# Altium schematic sheet PWR.SchDoc(PWR)
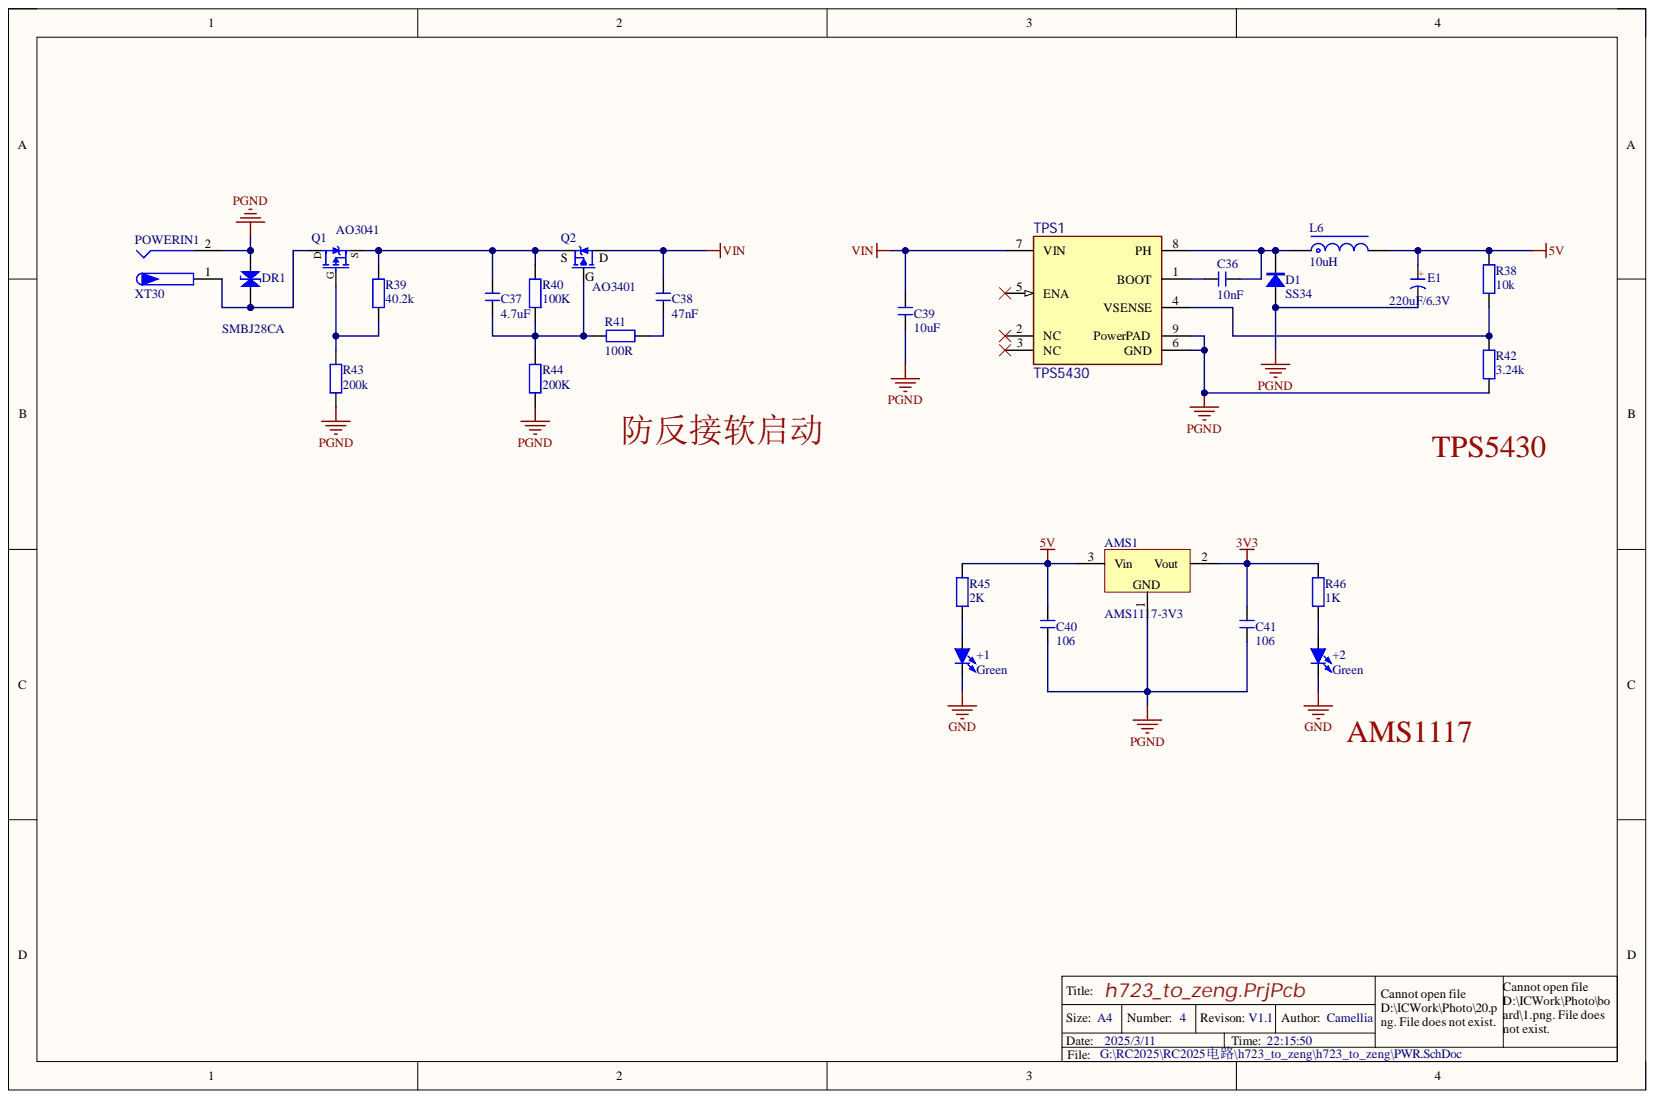
[diagram p0: PWR.SchDoc]

## Parts
+1  Green  [LED 0603G]
+2  Green  [LED 0603G]
AMS1  AMS1117-3V3  [SOT89_L]
C36  10nF  [C 0603_L]
C37  4.7uF  [C 0603_L]
C38  47nF  [C 0603_L]
C39  10uF  [C 1206_M]
C40  106  [C 0603_L]
C41  106  [C 0603_L]
D1  SS34  [SMA]
DR1  SMBJ28CA  [SMB]
E1  220uF/6.3V  [CD B(3528)]
L6  10uH  [NR6045]
POWERIN1  XT30  [XT30PW-M]
Q1  AO3041  [SOT23-3L]
Q2  AO3401  [SOT23-3L]
R38  10k  [R 0603_L]
R39  40.2k  [R 0603_L]
R40  100K  [R 0603_L]
R41  100R  [R 0603_L]
R42  3.24k  [R 0603_L]
R43  200k  [R 0603_L]
R44  200K  [R 0603_L]
R45  2K  [R 0603_L]
R46  1K  [R 0603_L]
TPS1  TPS5430  [ESOP8_N]

## Nets
3V3: AMS1.2 C41.2 R46.2
5V: AMS1.3 C40.2 E1.1 L6.2 R38.2 R45.2
GND: +1.2 +2.2
PGND: AMS1.1 C39.1 C40.1 C41.1 D1.1 DR1.1 E1.2 POWERIN1.2 R42.1 R43.1 R44.1 TPS1.6(GND) TPS1.9(PowerPAD)
VIN: C38.2 C39.2 Q2.3 TPS1.7(VIN)
Net+1_1: +1.1 R45.1
Net+2_1: +2.1 R46.1
NetC36_1: C36.1 TPS1.1(BOOT)
NetC36_2: C36.2 D1.2 L6.1 TPS1.8(PH)
NetC37_1: C37.1 Q2.1 R40.1 R41.2 R44.2
NetC37_2: C37.2 Q1.2 Q2.2 R39.2 R40.2
NetC38_1: C38.1 R41.1
NetDR1_2: DR1.2 POWERIN1.1 Q1.3
NetQ1_1: Q1.1 R39.1 R43.2
NetR38_1: R38.1 R42.2 TPS1.4(VSENSE)

Not connected: TPS1 2(NC) 3(NC) 5(ENA)

## Library data of the parts
+1 +2 = LED-SMD: 贴片LED; BOM名称: 贴片LED; Component Kind: Standard; Library Name: 9.1   - LED.SchLib
AMS1 = AMS1117: 三端稳压芯片; BOM名称: 稳压芯片; Component Kind: Standard; Library Name: 1.3   - 电源类芯片.SchLib
C36-C41 = C: 无极性贴片电容; 材质: X7R; BOM名称: 无极性贴片电容; Component Kind: Standard; Library Name: 3.1   - 电阻-电容-电感.SchLib
D1 = SS34: 肖特基二极管; 属性: 40V/3A; BOM名称: 肖特基二极管; Component Kind: Standard; Library Name: 8.1   - 二极管-整流桥.SchLib
DR1 = DR: 瞬态电压抑制二极管; BOM名称: 瞬态电压抑制二极管; Component Kind: Standard; Library Name: 8.1   - 二极管-整流桥.SchLib
E1 = C-CD: 贴片钽电容; BOM名称: 贴片钽电容; Component Kind: Standard; Library Name: 3.1   - 电阻-电容-电感.SchLib
L6 = L-NR: NR磁胶电感; BOM名称: NR磁胶电感; Component Kind: Standard; Library Name: 3.1   - 电阻-电容-电感.SchLib
POWERIN1 = XT电源接口-2Pins: 电源接口; BOM名称: XT航模电源接口; Component Kind: Standard; Library Name: 4.1   - 接口类.IntLib
Q1 Q2 = AO3401: P沟道 30V/4.2A; BOM名称: 场效应管; Component Kind: Standard; Library Name: 6.1   - 场效应管-可控硅.SchLib; 描述: P沟道 30V/4.2A
R38-R46 = R: 贴片电阻; BOM名称: 贴片电阻; Component Kind: Standard; Library Name: 3.1   - 电阻-电容-电感.SchLib
TPS1 = TPS5430DDA_1: 5.5V to 36V Input, 3A, 500kHz Step-Down Converter 8-SO PowerPAD 0 to 0; Component Kind: Standard; Library Name: 1.3   - 电源类芯片.SchLib
